# Altium schematic sheet USB.SchDoc(USB)
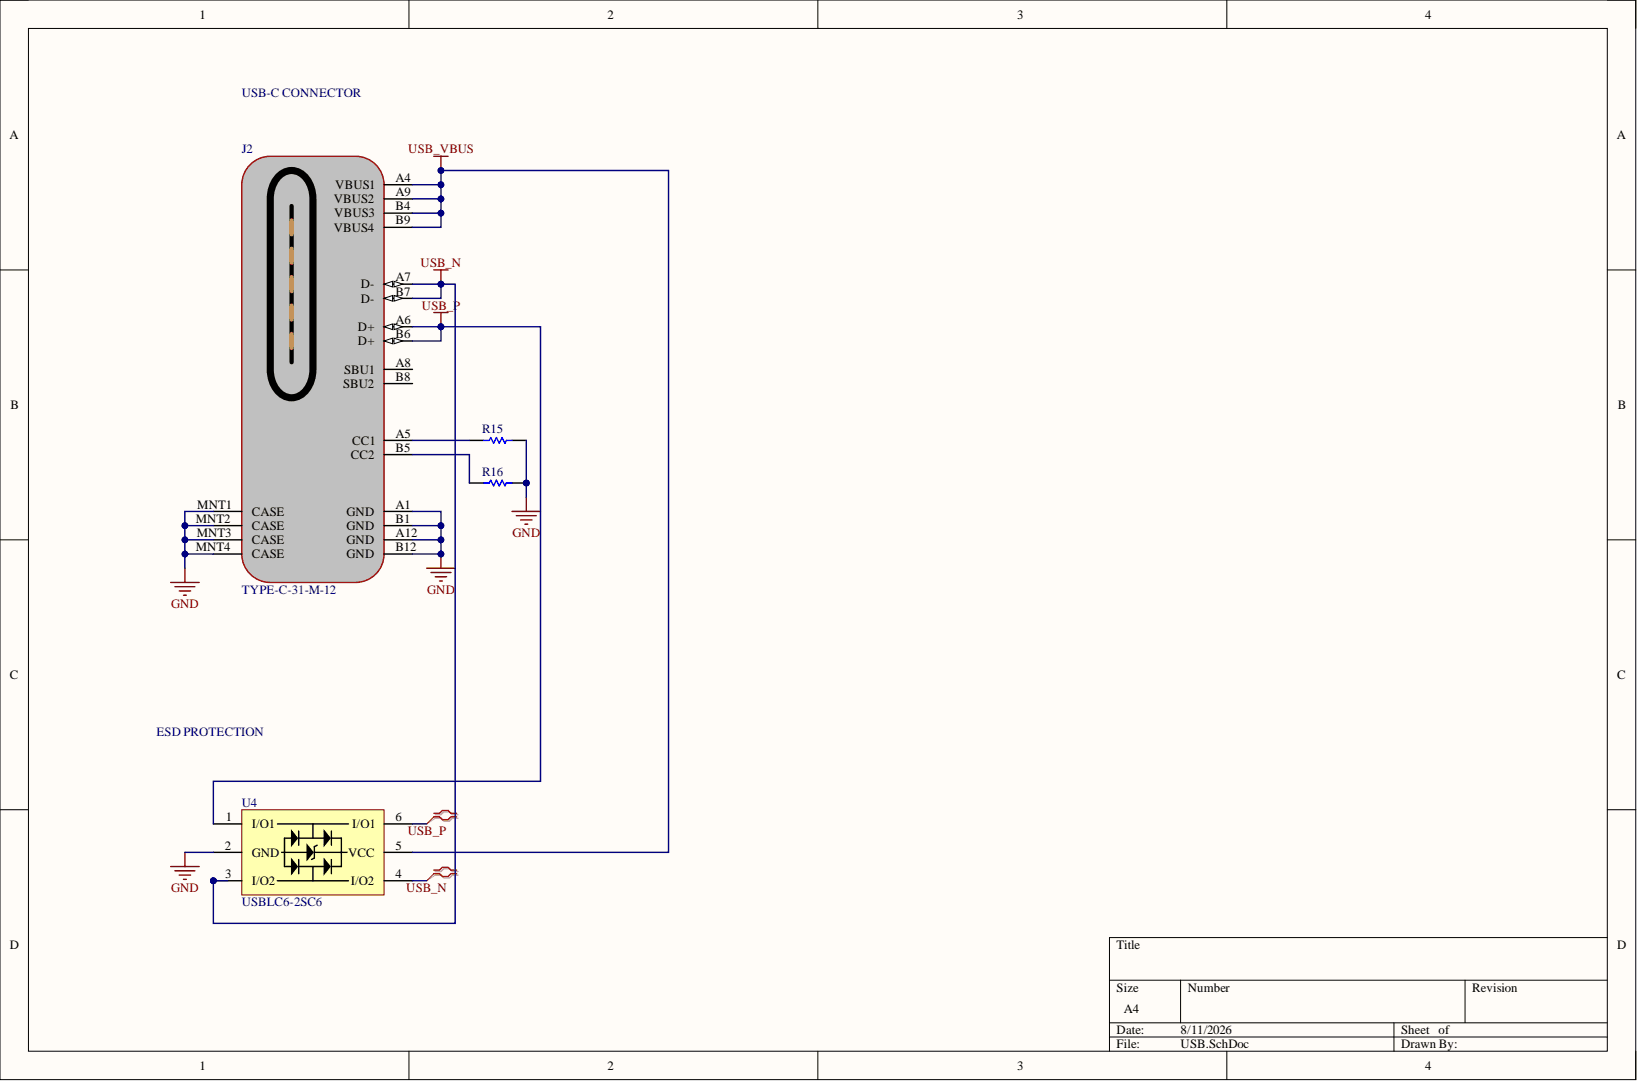
[diagram p0: USB.SchDoc]

## Parts
J2  TYPE-C-31-M-12
R15  Yageo RC0603FR-07 5K1L  [PCB-bfi54c2rahdo0sv3phdn-1]  (value not drawn)
R16  Yageo RC0603FR-07 5K1L  [PCB-bfi54c2rahdo0sv3phdn-1]  (value not drawn)
U4  USBLC6-2SC6  [PCB-5cahdzryvpldp615ujmr-1]

## Nets
GND: J2.A1(GND) J2.A12(GND) J2.B1(GND) J2.B12(GND) J2.MNT1(CASE) J2.MNT2(CASE) J2.MNT3(CASE) J2.MNT4(CASE) R15.2 R16.2 U4.2(GND)
USB_VBUS: J2.A4(VBUS1) J2.A9(VBUS2) J2.B4(VBUS3) J2.B9(VBUS4) U4.5(VCC)
USB_N: J2.A7(D-) J2.B7(D-) U4.3(I/O2) U4.4(I/O2)
USB_P: J2.A6(D+) J2.B6(D+) U4.1(I/O1) U4.6(I/O1)
NetJ2_A5: J2.A5(CC1) R15.1
NetJ2_B5: J2.B5(CC2) R16.1

Not connected: J2 A8(SBU1) B8(SBU2)

## Library data of the parts
J2 = CMP-a3766f9095887cb1-3: 250mA 1 16 Female Type-C SMD USB Connectors ROHS; Component Kind: Standard; JLCPCB Part #: C165948; Library Name: My Personal Space; Manufacturer Part Number: TYPE-C-31-M-12; Octopart Url: https://octopart.com/type-c-31-m-12-hro+electronics-99357592; OctopartID: a3766f9095887cb1
R15 R16 = CMP-cb9401c58a0b1453-1: Res Thick Film 1.6 x 0.8 mm 5.1K Ohm 1% 0.1W(1/10W) 100ppm/ C Molded SMD Paper T/R; Case/Package (SI): 1608; Lead-Free Status: Lead Free; Power Rating: 100 mW; Resistance: 5.10 kΩ; Voltage Rating (DC): 50.0 V; Case/Package: 0603; Component Kind: Standard; Library Name: My Personal Space; Manufacturer Part Number: RC0603FR-07 5K1L; Mounting Style: Surface Mount; Number of Pins: 2; Octopart URL: http://octopart.com/rc0603fr-07+5k1l-yageo-56321273; OctopartID: cb9401c58a0b1453; Packaging: Tape & Reel (TR), Cut Tape (CT); Resistance Tolerance: ±1%; RoHS: Compliant; Size-Height: 450 µm; Size-Length: 1.60 mm; Size-Width: 800 µm
U4 = CMP-756ebe39126caaa9-28: USBLC6 Series 2 Line 6 V Uni / Bi-Directional ESD Protection - SOT-23-6; Manufacturer: STMicroelectronics; Data Rate: 480 Mbps; Lead-Free Status: Lead Free; Operating Voltage: 6.00 V, 1.10 V; Case/Package: SOT-23; Component Kind: Standard; Library Name: My Personal Space; Lifecycle Status: Active; Manufacturer Part Number: USBLC6-2SC6; Mounting Style: Surface Mount; Number of Pins: 6; Octopart URL: https://octopart.com/usblc6-2sc6-stmicroelectronics-39666276; OctopartID: 756ebe39126caaa9; Operating Temperature: -50.0 °C to 125 °C; Packaging: Cut Tape (CT), Tape & Reel (TR); REACH SVHC Compliance: No SVHC; RoHS: Compliant
